# Altium schematic sheet UI.SchDoc
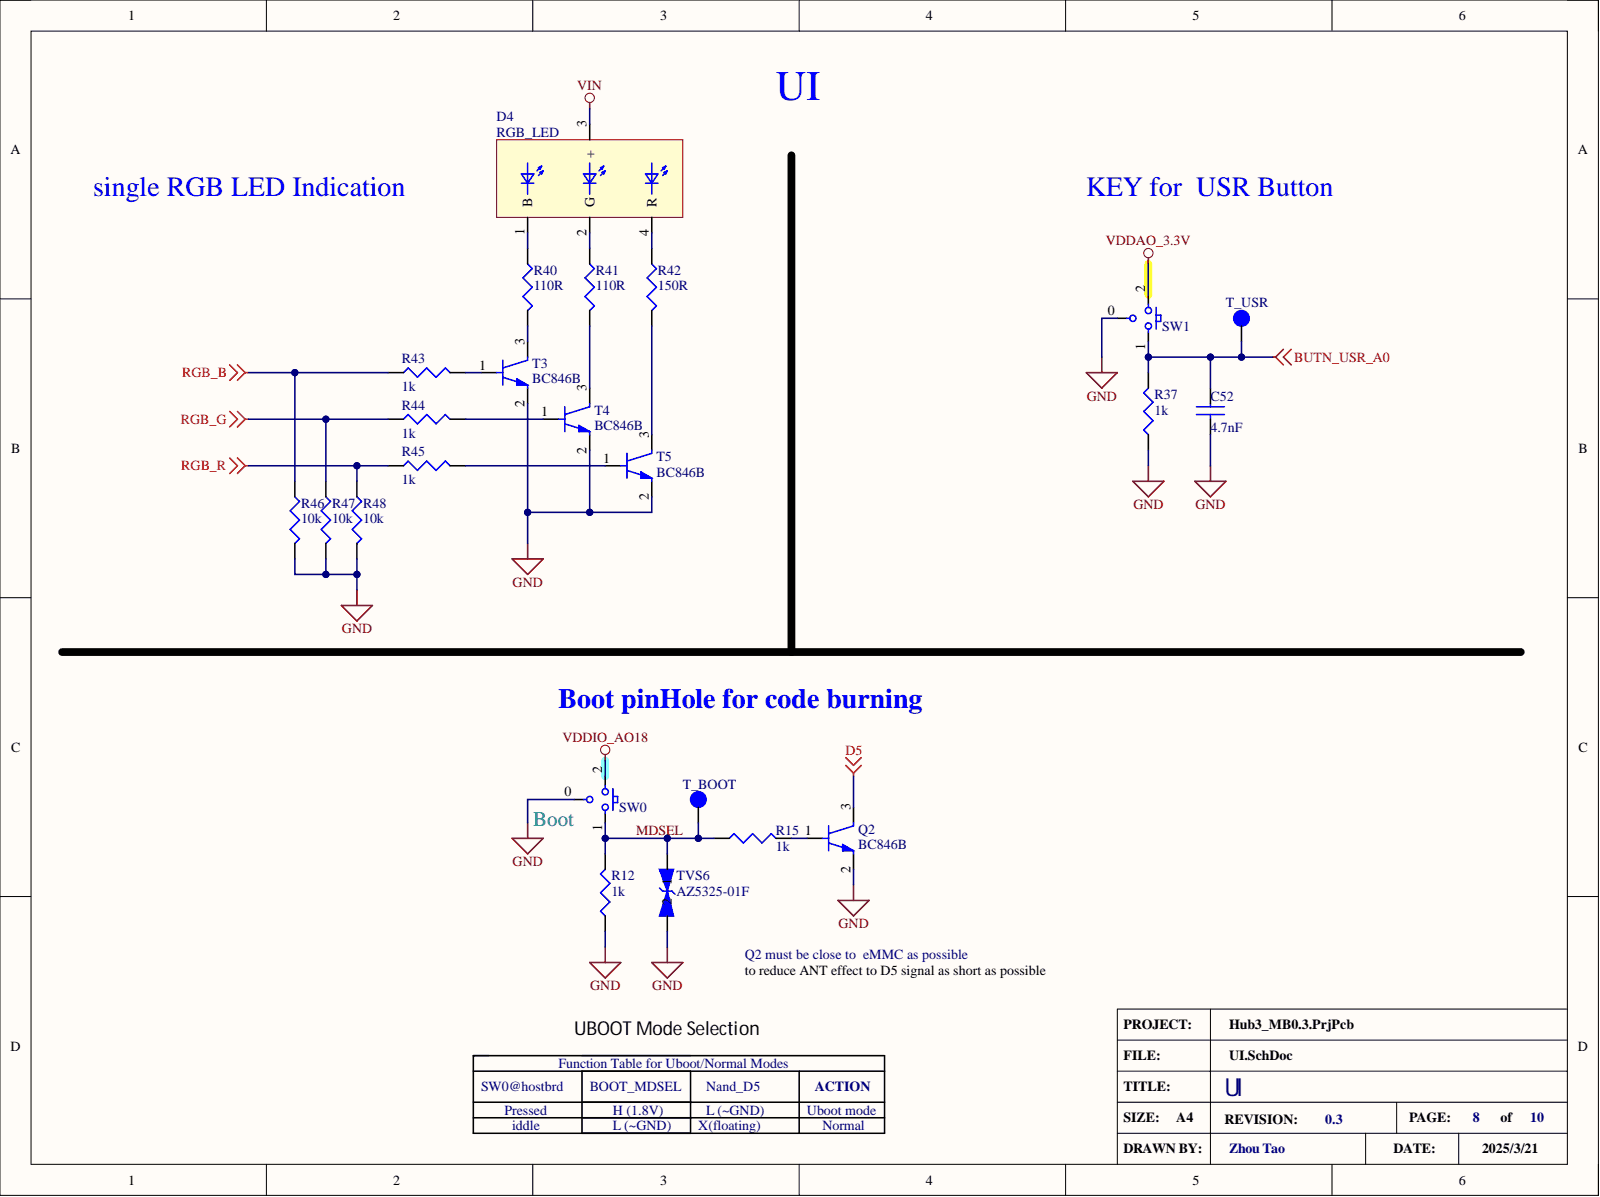
[diagram p0: UI.SchDoc]

## Parts
C52  4.7nF  [C0402]
D4  RGB_LED  [RGB_LED]
Q2  BC846B  [SOT-23]
R12  1k  [R0201]
R15  1k  [R0201]
R37  1k  [R0201]
R40  110R  [R0402]
R41  110R  [R0402]
R42  150R  [R0402]
R43  1k  [R0402]
R44  1k  [R0402]
R45  1k  [R0402]
R46  10k  [R0402]
R47  10k  [R0402]
R48  10k  [R0402]
SW0  TC115-4.5-H4.8  [4.5KEY]  (value not drawn)
SW1  TC115-4.5-H4.8  [4.5KEY]  (value not drawn)
T3  BC846B  [SOT23_3D]
T4  BC846B  [SOT23_3D]
T5  BC846B  [SOT23_3D]
T_BOOT  (no value)  [TP_D0.6mm]  (no BOM)
T_USR  (no value)  [TP_D0.6mm]  (no BOM)
TVS6  AZ5325-01F  [ESD0402]

## Nets
BUTN_USR_A0: C52.1 R37.2 SW1.1 T_USR.1
D5: Q2.3
GND: C52.2 Q2.2 R12.1 R37.1 R46.2 R47.2 R48.2 SW0.0 SW1.0 T3.2 T4.2 T5.2 TVS6.2
RGB_B: R43.1 R46.1
RGB_G: R44.1 R47.1
RGB_R: R45.1 R48.1
VDDAO_3.3V: SW1.2
VDDIO_AO18: SW0.2
VIN: D4.3(+)
MDSEL: R12.2 R15.1 SW0.1 T_BOOT.1 TVS6.1
NetD4_1: D4.1(B) R40.1
NetD4_2: D4.2(G) R41.1
NetD4_4: D4.4(R) R42.1
NetQ2_1: Q2.1 R15.2
NetR40_2: R40.2 T3.3
NetR41_2: R41.2 T4.3
NetR42_2: R42.2 T5.3
NetR43_2: R43.2 T3.1
NetR44_2: R44.2 T4.1
NetR45_2: R45.2 T5.1

## Library data of the parts
C52 = Cap_4.7nF_0402_50V: Manufacturer: Yageo; Part Number: AC0402KRX7R9BB472; Des: 4.7nF,0402,50V,10%,X7R; Component Kind: Standard; Library Name: BL706NLv0.1.SchLib; value: 4.7nF
D4 = RGB_LED: Manufacturer: everlight; Part Number: 19-237/R6GHBHC-A04/2T; Des: RGB LED,SMD-B, Vf: R2.2V,G3V,B3V; value: 19-237/R6GHBHC-A04/2T; Component Kind: Standard; Library Name: Lib_sch_Hubv3_2332.SchLib
Q2 = BC846B: Manufacturer: ON Semi; Part Number: BC846BLT1G; Des: BJT, NPN, 65V, 100mA, 100MHz; Component Kind: Standard; Library Name: Schlib_113X2_CoB0.1.SchLib; value: BC846B
R12 R15 R37 = RES_1K_0201_1%: Manufacturer: Yageo; Des: 1k,0201,1%,1/16w; Component Kind: Standard; Library Name: Schlib_113X2_CoB0.1.SchLib; value: 1k
R40 R41 = RES_110R_0402_1%: Manufacturer: Yageo; part number: RC0402FR-07110RL; Des: 110R, 0402 1% 1/16W; Component Kind: Standard; value: 110R; Library Name: Lib_sch_spk_V1_2020604.SchLib
R42 = RES_150R_0402_1%: Manufacturer: Yageo; part number: RC0402FR-07150RL; Des: 150R,0402,1%; Component Kind: Standard; value: 150R; Library Name: Lib_sch_spk_V1_2020604.SchLib
R43-R45 = RES_1k_0402_1%: Manufacturer: Yageo; part number: RC0402FR-071KL; Des: 1k,0402,1%,1/16w; Component Kind: Standard; value: 1k; Library Name: schlib_WBZ_hub.SchLib
R46-R48 = RES_10k_0402_1%: Manufacturer: Yageo; part number: RC0402FR-0710KL; Des: 10k,0402,1%; Component Kind: Standard; value: 10k; Library Name: schlib_WBZ_hub.SchLib
SW0 SW1 = Button_left side SMD: Manufacturer: Shenzhen GUOZHI; Part Number: TS4545DIP014; Des: 边3脚侧按键，H4.8mm, 4.5*4.5mm,260gF, +-0.25mm; Component Kind: Standard; value: TC115-4.5-H4.8; Library Name: Lib_sch_spk_V1_2020604.SchLib
T3-T5 = BC846B: Manufacturer: ON Semi; Part Number: BC846BLT1G; Des: BJT, NPN, 65V, 100mA, 100MHz; value: NPN, BC846B; Component Kind: Standard; Library Name: Lib_sch_Hubv3_2332.SchLib
T_BOOT T_USR = T_POINT_D0.6mm: Des: circle test pad (Dia=0.6mm ); Library Name: Lib_sch_spk_V0_181010.SchLib
TVS6 = TVS DIODE_AZ5325-01F: Manufacturer: Amazing; Des: 0.5pF Cin, VBR7V,5V,25V ESD clamping @8kV; Component Kind: Standard; Part Number: AZ5325-01F; value: AZ5325-01F; Library Name: Lib_sch_Hubv3_2332.SchLib
